# Altium schematic sheet Level Detector.SchDoc
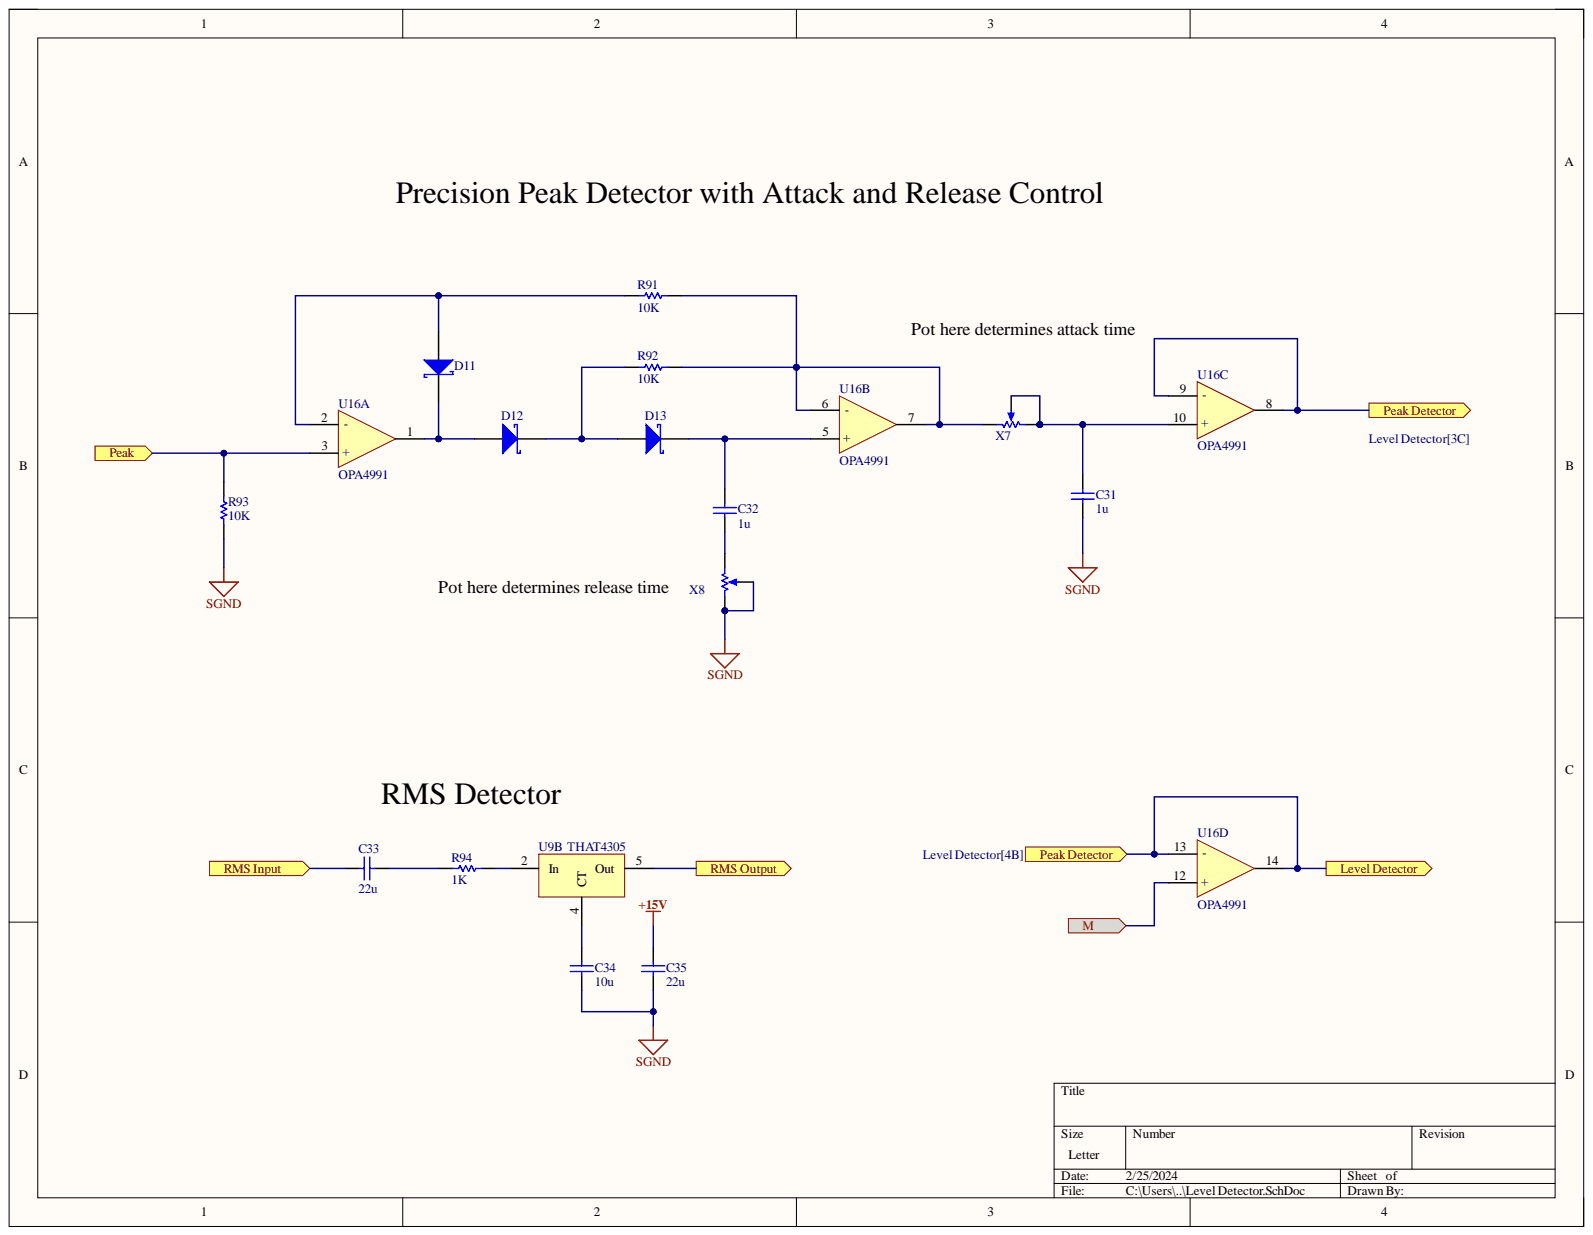
[diagram p0: Level Detector.SchDoc]

## Parts
C31  value 1u  (hidden comment: Capacitor)
C32  value 1u  (hidden comment: Capacitor)
C33  value 22u  (hidden comment: Capacitor)
C34  value 10u  (hidden comment: Capacitor)
C35  value 22u  (hidden comment: Capacitor)
D11  Schottky Diode  (value not drawn)
D12  Schottky Diode  (value not drawn)
D13  Schottky Diode  (value not drawn)
R91  value 10K  (hidden comment: Resistor)
R92  value 10K  (hidden comment: Resistor)
R93  value 10K  (hidden comment: Resistor)
R94  value 1K  (hidden comment: Resistor)
U9  THAT4305  [QSOP-16]  (part B)
U16  OPA4991  [FP-D0014A-IPC_B]  (parts A,B,C,D)
X7  Potentiometer  (value not drawn)
X8  Potentiometer  (value not drawn)

## Ports
Level Detector, M, Peak, Peak Detector, RMS Input, RMS Output

## Nets
+15V: C35.2
SGND: C31.1 C34.1 C35.1 R93.1 X8.2 X8.3
Level Detector: U16.8 U16.9(-) U16.13(-) U16.14 ; port Level Detector, port Peak Detector
M: U16.12(+) ; port M
Peak: R93.2 U16.3(+) ; port Peak
RMS Input: C33.2 ; port RMS Input
RMS Output: U9.5(Out) ; port RMS Output
NetC31_2: C31.2 U16.10(+) X7.2 X7.3
NetC32_1: C32.1 X8.1
NetC32_2: C32.2 D13.2 U16.5(+)
NetC33_1: C33.1 R94.1
NetC34_2: C34.2 U9.4(CT)
NetD11_1: D11.1 R91.2 U16.2(-)
NetD11_2: D11.2 D12.1 U16.1
NetD12_2: D12.2 D13.1 R92.2
NetR91_1: R91.1 R92.1 U16.6(-) U16.7 X7.1
NetR94_2: R94.2 U9.2(In)

## Library data of the parts
C31-C35 = Capacitor: SimulationGenericComponent: True; Component Kind: Standard; Description: Capacitor; Library Name: Simulation Generic Components.IntLib; Simulation: C_Capacitor_Default
D11-D13 = Schottky Diode: AF: 1; BV: 0; BV_MAX: 1e99; EG: 1.11; FC: 0.5; IBV: 0.001; IS: 1e-14; MJSW: 0.33; NTUN: 30; SimulationGenericComponent: True; TNOM: 27; XTI: 3; CJO: 0; CJP: 0; Component Kind: Standard; CTA: 0; CTP: 0; Description: Schottky Diode; FCS: 0.5; FV_MAX: 1e99; IKF: 0; IKR: 0; ISR: 1e-14; JSW: 0; JTUN: 0; JTUNSW: 0; KEG: 1; KF: 0; LEVEL: 1; Library Name: Simulation Generic Components.IntLib; N: 1; NBV: 1; NR: 1; NS: 1; PHP: 1; RS: 0; Simulation: diode; TCV: 0; TLEV: 0; TLEVC: 0; TM1: 0; TM2: 0; TPB: 0; TPHP: 0; TRS: 0; TRS2: 0; TT: 0; TTT1: 0; TTT2: 0; VJ: 1; XTITUN: 3
R91-R94 = Resistor: SimulationGenericComponent: True; TC1: 0; Component Kind: Standard; Description: Resistor; Library Name: Simulation Generic Components.IntLib; Simulation: R_Resistor_Default; TC2: 0
U9 = 4305Q16-U: Pre-trimmed Analog Engine® Dynamics Processor IC; Component Kind: Standard; Simulation: THAT4305; Library Name: DRC.SchLib
U16 = OPA4991IDR: 40-V, DUAL 4.5MHZ, RAIL-TO-RAIL; Component Kind: Standard; Library Name: DRC.SchLib
X7 X8 = Potentiometer: position: 0.5; SimulationGenericComponent: True; value: 1k; Component Kind: Standard; Description: Potentiometer; Library Name: Simulation Generic Components.IntLib; Simulation: POT
